# Altium schematic sheet MCUDEV_TFT_144.SchDoc(MCUDEV_TFT_144)
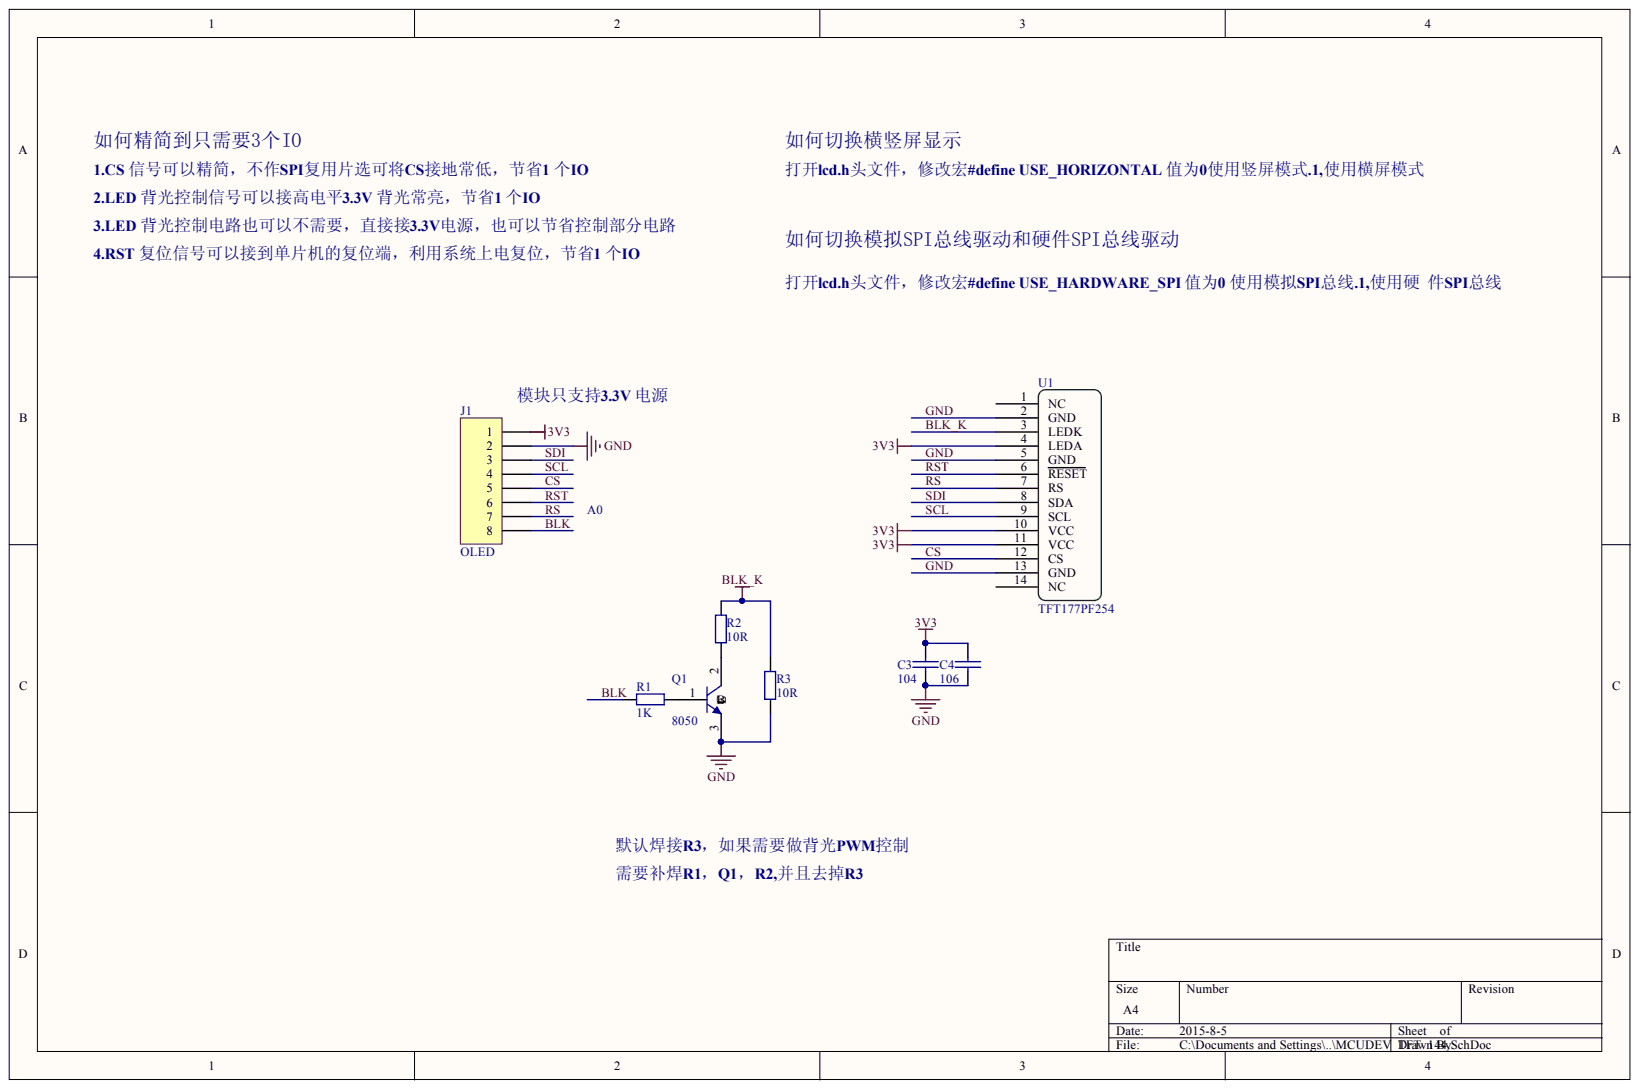
[diagram p0: MCUDEV_TFT_144.SchDoc]

## Parts
C3  104  [RC0603]
C4  106  [RC0805]
J1  OLED  [LCD_SPI8]
Q1  8050  [NPN]
R1  1K  [RC0603]
R2  10R  [RC0603]
R3  10R  [RC0603]
U1  TFT177PF254  [TFT177PF254]

## Nets
3V3: C3.1 C4.1 J1.1 U1.4(LEDA) U1.10(VCC) U1.11(VCC)
BLK: J1.8 R1.2
BLK_K: R2.2 R3.2 U1.3(LEDK)
CS: J1.5 U1.12(CS)
GND: C3.2 C4.2 J1.2 Q1.3 R3.1 U1.2(GND) U1.5(GND) U1.13(GND)
RS: J1.7 U1.7(RS)
RST: J1.6 U1.6(RESET)
SCL: J1.4 U1.9(SCL)
SDI: J1.3 U1.8(SDA)
NetQ1_1: Q1.1 R1.1
NetQ1_2: Q1.2 R2.1

Not connected: U1 1(NC) 14(NC)

## Library data of the parts
C3 C4 = CAP: Capacitor; Component Kind: Standard
J1 = Header 8: Header, 8-Pin; Component Kind: Standard; LatestRevisionDate: 17-Jul-2002; LatestRevisionNote: Re-released for DXP Platform.; Library Name: Miscellaneous Connectors.IntLib; Publisher: Altium Limited
Q1 = 2N3904: NPN General Purpose Amplifier; Component Kind: Standard; Library Name: Miscellaneous Devices.IntLib
R1-R3 = Res2: Resistor; Component Kind: Standard; Library Name: Miscellaneous Devices.IntLib
U1 = TFT177PF254: Component Kind: Standard; Library Name: 1.44寸串口液晶屏原理图封装_14Pin.SchLib
